# Altium schematic sheet IRController.SchDoc
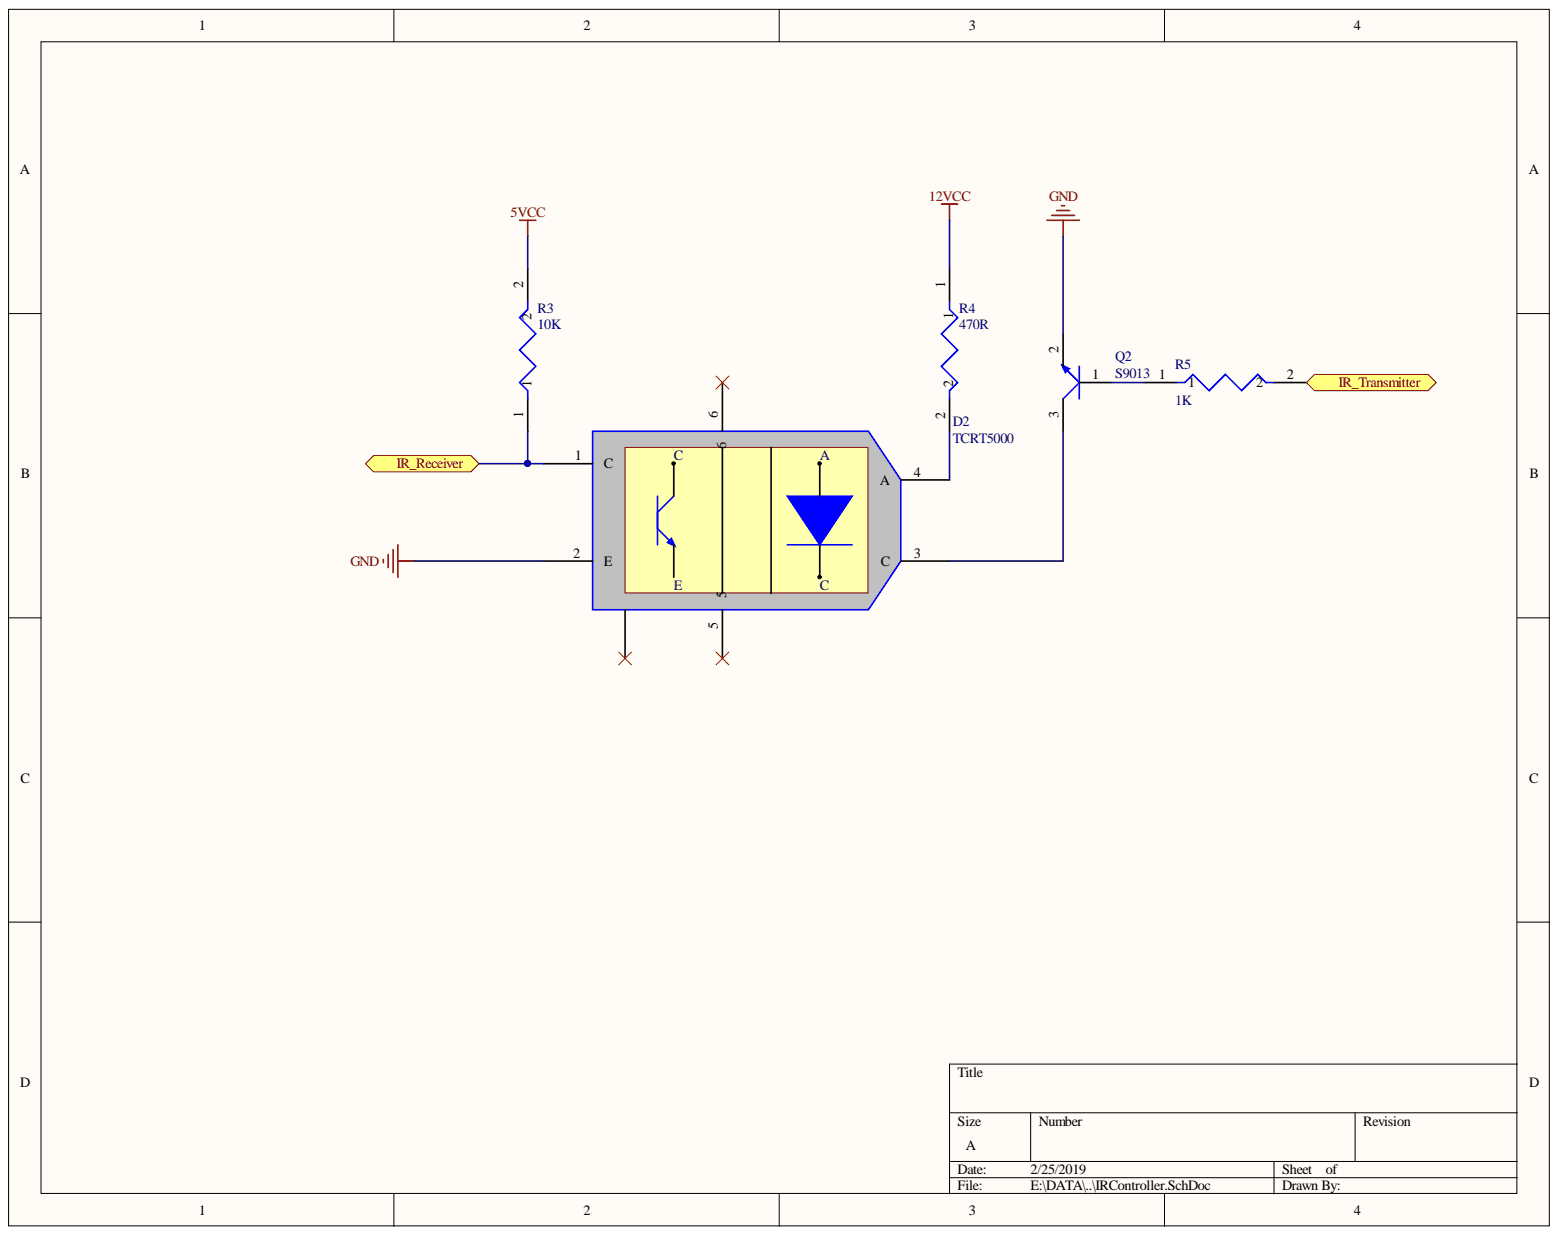
[diagram p0: IRController.SchDoc]

## Parts
D2  TCRT5000  [TCRT5000]
Q2  S9013  [SOT95P278X125-3N]
R3  10K  [RESC2608X06N_0603]
R4  470R  [RESC2608X06N_0603]
R5  1K  [RESC2608X06N_0603]

## Ports
IR_Receiver, IR_Transmitter

## Nets
5VCC: R3.2
12VCC: R4.1
GND: D2.2(E) Q2.2
IR_Receiver: D2.1(C) R3.1 ; port IR_Receiver
IR_Transmitter: R5.2 ; port IR_Transmitter
NetD2_3: D2.3(C) Q2.3
NetD2_4: D2.4(A) R4.2
NetQ2_1: Q2.1 R5.1

Not connected: D2 5 6 7

## Library data of the parts
Q2 = Transitor S9013 NPN: SOT-23 Plastic-Encapsulate Transistors; Component Kind: Standard; Library Name: Integrated_Library1.IntLib
R3 = R10k_0603_5%: RES SMD 10K OHM 1% 1/4W 0603; Component Kind: Standard; Library Name: Integrated_Library1.IntLib; Supplier 1: Digi-Key; Supplier Part Number 1: 541-10.0KSCT-ND
R4 = R470_0603_5%: Thick Film Resistors - SMD 470 ohm 5%; Component Kind: Standard; Library Name: Integrated_Library1.IntLib; Supplier 1: Digi-Key; Supplier Part Number 1: CR0603-JW-471ELFCT-ND
R5 = 1k_0603_5%: Thick Film Resistors - SMD 0603 1kOHMS 5% 1/10WATT; Component Kind: Standard; Library Name: Integrated_Library1.IntLib; Supplier 1: Digi-Key; Supplier Part Number 1: CR0603-JW-101ELFCT-ND
D2 = TCRT5000: Component Kind: Standard; Library Name: Integrated_Library1.IntLib
